# Altium schematic sheet CAN2XG.SchDoc
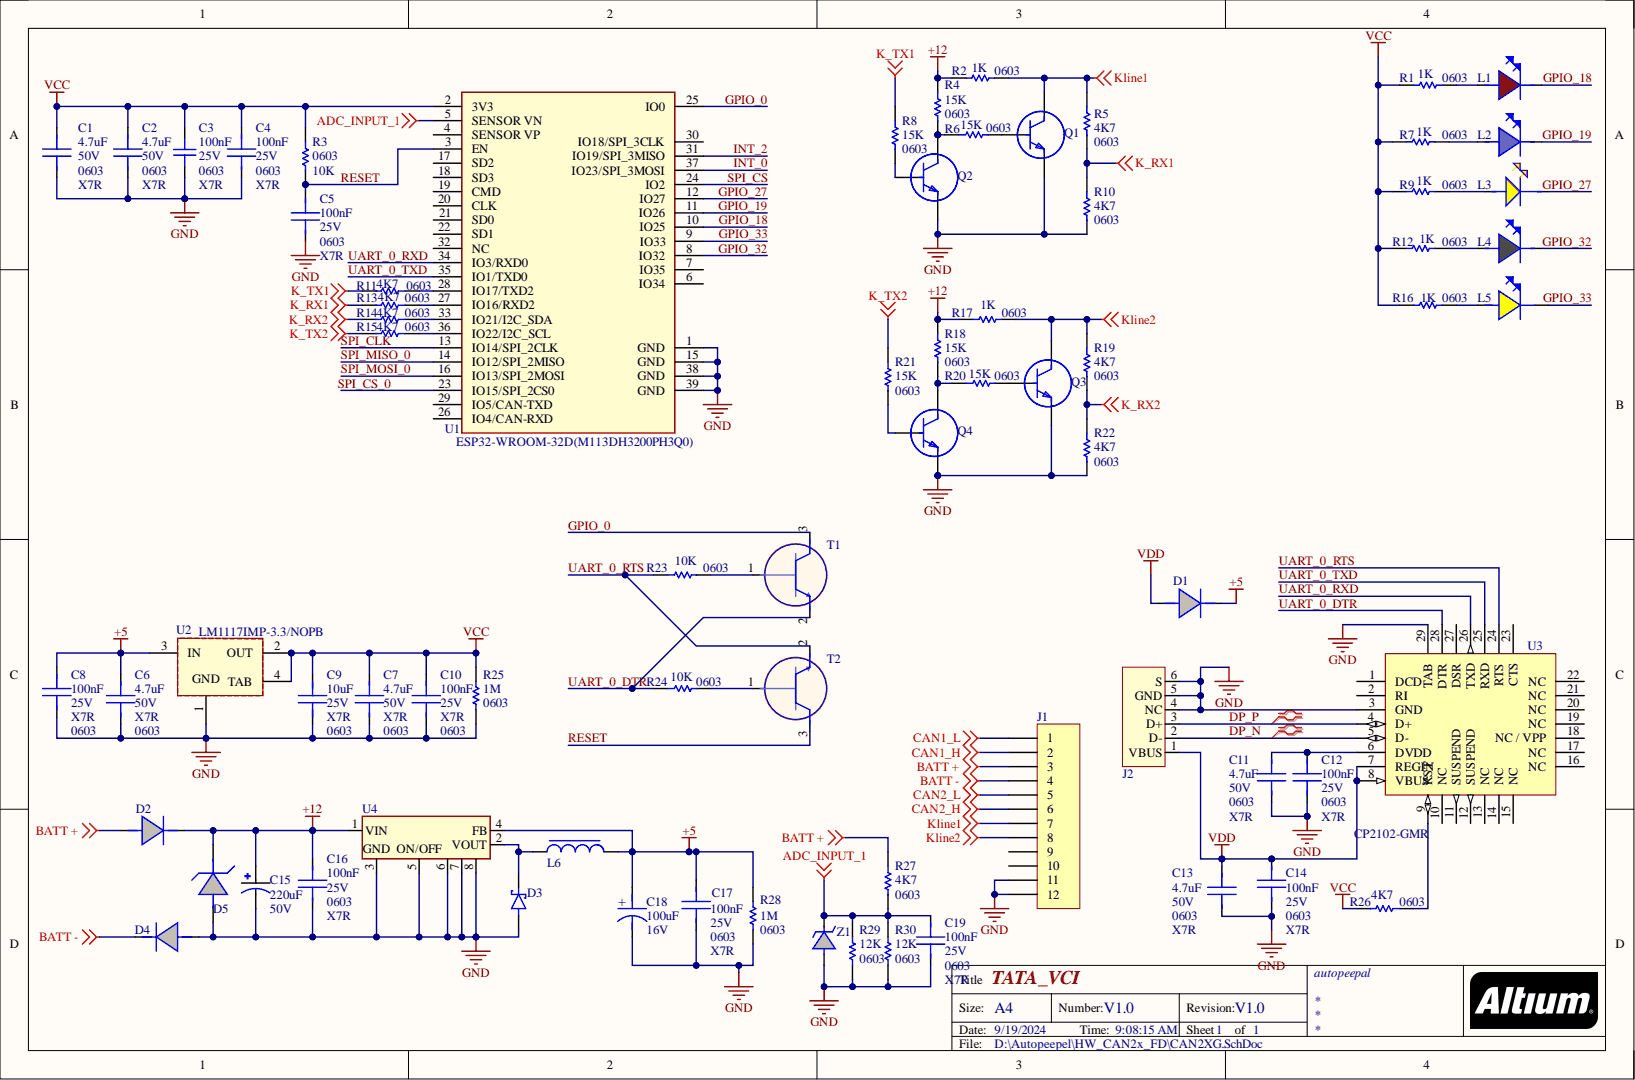
[diagram p0: CAN2XG.SchDoc]

## Parts
C1  value 4.7uF  Case/Package: 0603  Dielectric: X7R  Voltage: 50V  [CAP-0603]
C2  value 4.7uF  Case/Package: 0603  Dielectric: X7R  Voltage: 50V  [CAP-0603]
C3  value 100nF  Case/Package: 0603  Dielectric: X7R  Voltage: 25V  [CAP-0603]
C4  value 100nF  Case/Package: 0603  Dielectric: X7R  Voltage: 25V  [CAP-0603]
C5  value 100nF  Case/Package: 0603  Dielectric: X7R  Voltage: 25V  [CAP-0603]
C6  value 4.7uF  Case/Package: 0603  Dielectric: X7R  Voltage: 50V  [CAP-0603]
C7  value 4.7uF  Case/Package: 0603  Dielectric: X7R  Voltage: 50V  [CAP-0603]
C8  value 100nF  Case/Package: 0603  Dielectric: X7R  Voltage: 25V  [CAP-0603]
C9  value 10uF  Case/Package: 0603  Dielectric: X7R  Voltage: 25V  [CAP-0603]
C10  value 100nF  Case/Package: 0603  Dielectric: X7R  Voltage: 25V  [CAP-0603]
C11  value 4.7uF  Case/Package: 0603  Dielectric: X7R  Voltage: 50V  [CAP-0603]
C12  value 100nF  Case/Package: 0603  Dielectric: X7R  Voltage: 25V  [CAP-0603]
C13  value 4.7uF  Case/Package: 0603  Dielectric: X7R  Voltage: 50V  [CAP-0603]
C14  value 100nF  Case/Package: 0603  Dielectric: X7R  Voltage: 25V  [CAP-0603]
C15  value 220uF  Voltage: 50V  [C200uF 10x10.2]
C16  value 100nF  Case/Package: 0603  Dielectric: X7R  Voltage: 25V  [CAP-0603]
C17  value 100nF  Case/Package: 0603  Dielectric: X7R  Voltage: 25V  [CAP-0603]
C18  value 100uF  Voltage: 16V  [C100uF]
C19  value 100nF  Case/Package: 0603  Dielectic: X7R  Voltage: 25V  [CAP-0603]
D1  (no value)  [DO214]
D2  (no value)  [DO214]
D3  (no value)  [DO214]
D4  (no value)  [DO214]
D5  (no value)  [DO214]
J1  (no value)  [HDR1X12]
J2  (no value)  [1734035-2USB]
L1  (no value)  [LEDRED0603]
L2  (no value)  [LEDBLUE0603]
L3  (no value)  [LEDRED0603]
L4  (no value)  [LEDGREEN0603]
L5  (no value)  [LEDRED0603]
L6  (no value)  [IND-WE-PD 1050-10X10X5MM]
Q1  (no value)  [SOT95P245X93-3N]
Q2  (no value)  [SOT95P245X93-3N]
Q3  (no value)  [SOT95P245X93-3N]
Q4  (no value)  [SOT95P245X93-3N]
R1  value 1K  Case/Package: 0603  [RESC1608X55X30ML15T15]
R2  value 1K  Case/Package: 0603  [RESC1608X55X30ML15T15]
R3  value 10K  Case/Package: 0603  [RESC1608X55X30ML15T15]
R4  value 15K  Case/Package: 0603  [RESC1608X55X30ML15T15]
R5  value 4K7  Case/Package: 0603  [RESC1608X55X30ML15T15]
R6  value 15K  Case/Package: 0603  [RESC1608X55X30ML15T15]
R7  value 1K  Case/Package: 0603  [RESC1608X55X30ML15T15]
R8  value 15K  Case/Package: 0603  [RESC1608X55X30ML15T15]
R9  value 1K  Case/Package: 0603  [RESC1608X55X30ML15T15]
R10  value 4K7  Case/Package: 0603  [RESC1608X55X30ML15T15]
R11  value 4K7  Case/Package: 0603  [RESC1608X55X30ML15T15]
R12  value 1K  Case/Package: 0603  [RESC1608X55X30ML15T15]
R13  value 4K7  Case/Package: 0603  [RESC1608X55X30ML15T15]
R14  value 4K7  Case/Package: 0603  [RESC1608X55X30ML15T15]
R15  value 4K7  Case/Package: 0603  [RESC1608X55X30ML15T15]
R16  value 1K  Case/Package: 0603  [RESC1608X55X30ML15T15]
R17  value 1K  Case/Package: 0603  [RESC1608X55X30ML15T15]
R18  value 15K  Case/Package: 0603  [RESC1608X55X30ML15T15]
R19  value 4K7  Case/Package: 0603  [RESC1608X55X30ML15T15]
R20  value 15K  Case/Package: 0603  [RESC1608X55X30ML15T15]
R21  value 15K  [RESC1608X55X30ML15T15]
R22  value 4K7  Case/Package: 0603  [RESC1608X55X30ML15T15]
R23  value 10K  Case/Package: 0603  [RESC1608X55X30ML15T15]
R24  value 10K  Case/Pacake: 0603  [RESC1608X55X30ML15T15]
R25  value 1M  Case/Package: 0603  [RESC1608X55X30ML15T15]
R26  value 4K7  Case/Package: 0603  [RESC1608X55X30ML15T15]
R27  value 4K7  Case/Package: 0603  [RESC1608X55X30ML15T15]
R28  value 1M  Case/Package: 0603  [RESC1608X55X30ML15T15]
R29  value 12K  Case/Package: 0603  [RESC1608X55X30ML15T15]
R30  value 12K  Case/Package: 0603  [RESC1608X55X30ML15T15]
T1  (no value)  [2N2222]
T2  (no value)  [2N2222]
U1  (no value)  [Espressif_Systems_ESP32-WROOM-32D_MFG]
U2  (no value)  [SOT223]
U3  (no value)  [QFN50P500X500X100_HS-29N]
U4  (no value)  [to-263]
Z1  (no value)  [SOD80]

## Nets
+5: C6.2 C8.2 C17.2 C18.1 D1.1 L6.2 R28.2 U2.3(IN) U4.4(FB)
+12: C15.1 C16.2 D2.1 D5.1 R2.2 R4.2 R17.2 R18.2 U4.1(VIN)
ADC_INPUT_1: C19.2 R27.1 R29.2 R30.2 U1.5(SENSOR_VN) Z1.1
BATT +: D2.2 J1.3 R27.2
BATT -: D4.1 J1.4
CAN1_H: J1.2
CAN1_L: J1.1
CAN2_H: J1.6
CAN2_L: J1.5
GND: C1.1 C2.1 C3.1 C4.1 C5.1 C6.1 C7.1 C8.1 C9.1 C10.1 C11.1 C12.1 C13.1 C14.1 C15.2 C16.1 C17.1 C18.2 C19.1 D3.2 D4.2 D5.2 J1.11 J1.12 J2.4(NC) J2.5(GND) J2.6(S) Q1.2 Q2.2 Q3.2 Q4.2 R10.1 R22.1 R25.1 R28.1 R29.1 R30.1 U1.1(GND) U1.15(GND) U1.38(GND) U1.39(GND) U2.1 U3.3(GND) U3.29(TAB) U4.3 U4.5 U4.6 U4.7 U4.8 Z1.2
K_RX1: R5.1 R10.2 R13.1
K_RX2: R14.1 R19.1 R22.2
K_TX1: R8.2 R11.1
K_TX2: R15.1 R21.2
Kline1: J1.7 Q1.3 R2.1 R5.2
Kline2: J1.8 Q3.3 R17.1 R19.2
VCC: C1.2 C2.2 C3.2 C4.2 C7.2 C9.2 C10.2 R1.1 R3.2 R7.1 R9.1 R12.1 R16.1 R25.2 R26.1 U1.2(3V3) U2.2(OUT) U2.4(TAB)
VDD: C13.2 C14.2 D1.2 J2.1(VBUS) U3.7(REGIN) U3.8(VBUS)
DP_N: J2.2(D-) U3.5(D-)
DP_P: J2.3(D+) U3.4(D+)
GPIO_0: T1.3 U1.25(IO0)
GPIO_18: L1.1 U1.10(IO25)
GPIO_19: L2.1 U1.11(IO26)
GPIO_27: L3.1 U1.12(IO27)
GPIO_32: L4.1 U1.8(IO32)
GPIO_33: L5.1 U1.9(IO33)
INT_0: U1.37(IO23/SPI_3MOSI)
INT_2: U1.31(IO19/SPI_3MISO)
RESET: C5.2 R3.1 T2.3 U1.3(EN)
SPI_CLK: U1.13(IO14/SPI_2CLK)
SPI_CS: U1.24(IO2)
SPI_CS_0: U1.23(IO15/SPI_2CS0)
SPI_MISO_0: U1.14(IO12/SPI_2MISO)
SPI_MOSI_0: U1.16(IO13/SPI_2MOSI)
UART_0_DTR: R24.2 T1.2 U3.28(DTR)
UART_0_RTS: R23.2 T2.2 U3.24(RTS)
UART_0_RXD: U1.34(IO3/RXD0) U3.26(TXD)
UART_0_TXD: U1.35(IO1/TXD0) U3.25(RXD)
NetC11_2: C11.2 C12.2 U3.6(DVDD)
NetD3_1: D3.1 L6.1 U4.2(VOUT)
NetL1_2: L1.2 R1.2
NetL2_2: L2.2 R7.2
NetL3_2: L3.2 R9.2
NetL4_2: L4.2 R12.2
NetL5_2: L5.2 R16.2
NetQ1_1: Q1.1 R6.1
NetQ2_1: Q2.1 R8.1
NetQ2_3: Q2.3 R4.1 R6.2
NetQ3_1: Q3.1 R20.1
NetQ4_1: Q4.1 R21.1
NetQ4_3: Q4.3 R18.1 R20.2
NetR11_2: R11.2 U1.28(IO17/TXD2)
NetR13_2: R13.2 U1.27(IO16/RXD2)
NetR14_2: R14.2 U1.33(IO21/I2C_SDA)
NetR15_2: R15.2 U1.36(IO22/I2C_SCL)
NetR23_1: R23.1 T1.1
NetR24_1: R24.1 T2.1
NetR26_2: R26.2 U3.9(RST)

Not connected: J1 9 10; U1 4(SENSOR_VP) 6(IO34) 7(IO35) 17(SD2) 18(SD3) 19(CMD) 20(CLK) 21(SD0) 22(SD1) 26(IO4/CAN-RXD) 29(IO5/CAN-TXD) 30(IO18/SPI_3CLK) 32(NC); U3 1(DCD) 2(RI) 10(NC) 11(SUSPEND) 12(SUSPEND) 13(NC) 14(NC) 15(NC) 16(NC) 17(NC) 18(NC_/_VPP) 19(NC) 20(NC) 21(NC) 22(NC) 23(CTS) 27(DSR)

## Library data of the parts
C1 C2 C6 C7 C11 C13 = C4.7uF 0603: CAP CER 4.7uF 50V X7R 0603; Component Kind: Standard; Library Name: MyLib.SCHLIB
C3 C5 = C1uF 0603: CAP CER 100nF 25V X7R 0603; Component Kind: Standard; Library Name: MyLib.SCHLIB
C4 C8 C10 C12 C14 C16 C17 C19 = C100nF 0603: CAP CER 100nF 25V X7R 0603; Component Kind: Standard; Library Name: MyLib.SCHLIB
C9 = C10uF  0603: CAP CER 10uF 25V  0603; Component Kind: Standard; Library Name: MyLib.SCHLIB
C15 = C200uF 10x10.2: 220uF 50V 10x10.5mm SMD Electrolytic Capacitor; Component Kind: Standard; Library Name: FotaxLite.SCHLIB
C18 = C100uF: 100uF 16V (107C B3528) Tantalum; Component Kind: Standard; Library Name: MyLib.SCHLIB
D1 = 1N4007: DO214 SMD Version of 1N4007 Forward Current: 1A Max Repititive Reverse Voltage: 1000 V Max RMS Voltage: 700V  Max DC Blocking Voltage: 1000V; Component Kind: Standard; ComponentLink1Description: Supplier Link; ComponentLink1URL: https://www.ktron.in/product/m7-diode-sma/; Library Name: MyLib.SCHLIB; Manufacturer Part Number: 1N4007
D2 = 1N4007: DO214 SMD Version of 1N4007 Forward Current: 1A Max Repititive Reverse Voltage: 1000 V Max RMS Voltage: 700V  Max DC Blocking Voltage: 1000V Max instantaneous forward voltage: 1.1 V; Component Kind: Standard; ComponentLink1Description: Supplier Link; ComponentLink1URL: https://www.ktron.in/product/m7-diode-sma/; Library Name: MyLib.SCHLIB; Maunfacturer Part Number: 1N4007
D3 = SS34-E3/57T: Schottky Diodes & Rectifiers 3.0 Amp 40 Volt; Component Kind: Standard; Library Name: MyLib.SCHLIB; Manufacturer Part Number: SS34-E3/57T
D4 = 1N4007: DO214 SMD Version of 1N4007 Forward Current: 1A Max Repititive Reverse Voltage: 1000 V Max RMS Voltage: 700V Max DC Blocking Voltage: 1000V; Component Kind: Standard; ComponentLink1Description: Supplier Link; ComponentLink1URL: https://www.ktron.in/product/m7-diode-sma/; Library Name: MyLib.SCHLIB; Manufacturer Part Number: 1N4007
D5 = SMBJ TVS Diode: ESD Protection Diodes / TVS Diodes 600W 200V 5% Uni-Directional; Manufature Part Number: SMBJ200A; Component Kind: Standard; Library Name: MyLib.SCHLIB
J1 = Header 12: Header, 12-Pin; Component Kind: Standard; Library Name: Miscellaneous Connectors.IntLib; Signal Integrity: Connector
J2 = 1734035-2: CONN RCPT USB2.0 MINI B SMD R/A; Component Kind: Standard; Library Name: MyLib.SCHLIB; Manufacturer Part number: 1734035-2
L1 = IN-S63ATR: LED RED CLEAR 0603 SMD; Case/Packahe: 0603; Component Kind: Standard; ComponentLink1Description: http://www.inolux-corp.com/datasheet/SMDLED/Mono%20Color%20Top%20View/IN-S63AT%20Series_V1.1.pdf?src-supplier=Digi-Key; ComponentLink1URL: http://www.inolux-corp.com/datasheet/SMDLED/Mono%20Color%20Top%20View/IN-S63AT%20Series_V1.1.pdf?src-supplier=Digi-Key; Library Name: MyLib.SCHLIB; Manufacturer Part Number: IN-S63ATR
L2 = IN-S63AT5B: LED BLUE CLEAR 0603 SMD; Case/Package: 0603; Component Kind: Standard; ComponentLink1Description: http://www.inolux-corp.com/datasheet/SMDLED/Mono%20Color%20Top%20View/IN-S63AT%20Series_V1.1.pdf?src-supplier=Digi-Key; ComponentLink1URL: http://www.inolux-corp.com/datasheet/SMDLED/Mono%20Color%20Top%20View/IN-S63AT%20Series_V1.1.pdf?src-supplier=Digi-Key; Library Name: MyLib.SCHLIB; Manufacturer Part Number: IN-S63AT5B
L3 = LED_Orange0603: LED ORANGE CLEAR 0603 SMD; Case/Packahe: 0603; Component Kind: Standard; Library Name: MyLib.SCHLIB
L4 = IN-S63AT5G: LED GREEN CLEAR 0603 SMD; Case/Packahe: 0603; Component Kind: Standard; ComponentLink1Description: http://www.inolux-corp.com/datasheet/SMDLED/Mono%20Color%20Top%20View/IN-S63AT%20Series_V1.1.pdf?src-supplier=Digi-Key; ComponentLink1URL: http://www.inolux-corp.com/datasheet/SMDLED/Mono%20Color%20Top%20View/IN-S63AT%20Series_V1.1.pdf?src-supplier=Digi-Key; Library Name: MyLib.SCHLIB; Manufacturer Part Number: IN-S63AT5G
L5 = LED Y 0603: LED YELLOW CLEAR 0603 SMD; Manufacturer Part Number: IN-S63AT5Y; Case/Packahe: 0603; Component Kind: Standard; Library Name: MyLib.SCHLIB
L6 = L68uH inductor: 68uH; Component Kind: Standard; Library Name: MyLib.SCHLIB; Value: 68uH
Q1-Q4 = MMBT2222ALT1G: TRANS NPN 40V 0.6A SOT23-3; Case/Package: SOT-23-3; Component Kind: Standard; Library Name: MyLib.SCHLIB; Manufacturer Part Number: MMBT2222ALT1G
  Q2-Q4: ComponentLink1Description: https://datasheet.ciiva.com/16199/mmbt2222lt1-d-16199072.pdf?src-supplier=Future+Electronics; ComponentLink1URL: https://datasheet.ciiva.com/16199/mmbt2222lt1-d-16199072.pdf?src-supplier=Future+Electronics; ComponentLink2Description: https://datasheet.ciiva.com/15139/getdatasheetpartid-82691-15139077.pdf?src-supplier=Verical; ComponentLink2URL: https://datasheet.ciiva.com/15139/getdatasheetpartid-82691-15139077.pdf?src-supplier=Verical; ComponentLink3Description: https://datasheet.ciiva.com/7972/mmbt2222lt1-d-7972057.pdf?src-supplier=Element14; ComponentLink3URL: https://datasheet.ciiva.com/7972/mmbt2222lt1-d-7972057.pdf?src-supplier=Element14; ComponentLink4Description: https://datasheet.ciiva.com/7972/mmbt2222lt1-d-7972058.pdf?src-supplier=Element14; ComponentLink4URL: https://datasheet.ciiva.com/7972/mmbt2222lt1-d-7972058.pdf?src-supplier=Element14; ComponentLink5Description: https://datasheet.ciiva.com/14546/70099528-14546715.pdf?src-supplier=Allied+Electronics; ComponentLink5URL: https://datasheet.ciiva.com/14546/70099528-14546715.pdf?src-supplier=Allied+Electronics; ComponentLink6Description: https://datasheet.ciiva.com/25998/mmbt2222lt1-d-25998815.pdf?src-supplier=Avnet+Europe; ComponentLink6URL: https://datasheet.ciiva.com/25998/mmbt2222lt1-d-25998815.pdf?src-supplier=Avnet+Europe; ComponentLink7Description: https://datasheet.ciiva.com/976/mmbt2222lt1-d-976344.pdf?src-supplier=Digi-Key; ComponentLink7URL: https://datasheet.ciiva.com/976/mmbt2222lt1-d-976344.pdf?src-supplier=Digi-Key; ComponentLink8Description: https://datasheet.ciiva.com/976/mmbt2222lt1-d-976345.pdf?src-supplier=Digi-Key; ComponentLink8URL: https://datasheet.ciiva.com/976/mmbt2222lt1-d-976345.pdf?src-supplier=Digi-Key; ComponentLink9Description: https://datasheet.ciiva.com/976/mmbt2222lt1-d-976346.pdf?src-supplier=Digi-Key; ComponentLink9URL: https://datasheet.ciiva.com/976/mmbt2222lt1-d-976346.pdf?src-supplier=Digi-Key; ComponentLink10Description: https://datasheet.ciiva.com/11201/mmbt2222lt1-d-11201869.pdf?src-supplier=Avnet; ComponentLink10URL: https://datasheet.ciiva.com/11201/mmbt2222lt1-d-11201869.pdf?src-supplier=Avnet
R1 R7 R9 R12 R16 = R1K 0603: Thick Film Resistor -  1K, 1%, 0603; Component Kind: Standard; Library Name: MyLib.SCHLIB
R2 R17 = R1K 0603: Thick Film Resistor -  1K ohm, 1%, 0603; Component Kind: Standard; Library Name: MyLib.SCHLIB
R3 = R10K 0603: Thick Film Resistor -  10Kohm, 1%, 0603; Component Kind: Standard; Library Name: MyLib.SCHLIB
R4 R6 R8 R18 R20 R21 = R_15K: Thick Film Resistor -  15Kohm, 1%, 0603; Component Kind: Standard; Library Name: MyLib.SCHLIB
  R21: Case/Package: 0603
R5 R10 R26 = R_4k7: Thick Film Resistor -  4K7 ohm, 1%, 0603; Component Kind: Standard; Library Name: MyLib.SCHLIB
R11 R13-R15 = R_4k7: Thick Film Resistor -  4K7, 1%, 0603; Component Kind: Standard; Library Name: MyLib.SCHLIB
R19 R22 = R_4k7: Thick Film Resistor -  4K7ohm, 1%, 0603; Component Kind: Standard; Library Name: MyLib.SCHLIB
R23 R24 = R10K 0603: Thick Film Resistor -  10K, 1%, 0603; Component Kind: Standard; Library Name: MyLib.SCHLIB
R25 R28 = R1MOhm 0603: Thick Film Resistor -  10M, 1%, 0603; Component Kind: Standard; Library Name: MyLib.SCHLIB
R27 = R_47K: Thick Film Resistor -  4K7 ohm, 1%, 0603; Component Kind: Standard; Library Name: MyLib.SCHLIB
R29 R30 = R12K 0603: Thick Film Resistor -  12K ohm, 1%, 0603; Component Kind: Standard; Library Name: MyLib.SCHLIB
T1 T2 = MMBT2222: 2TRANS NPN 30V 0.6A SOT23-3; Component Kind: Standard; Library Name: MyLib.SCHLIB; Manufacturer Part Number: MMBT2222
U1 = ESP32-WROOM-32D(M113DH3200PH3Q0): WiFi Modules (802.11) SMD Module, ESP32-D0WD, 32Mbits SPI 4MB flash, UART mode, PCB antenna; Component Kind: Standard; ComponentLink1Description: Link; ComponentLink1URL: https://www.mouser.com/ProductDetail/Espressif-Systems/ESP32-WROOM-32D-N4?qs=Li%252BoUPsLEntUgv1ft2QYWQ%3D%3D; Library Name: MyLib.SCHLIB; Manufacturer Part Number: ESP32-WROOM-32D(M113DH3200PH3Q0)
U2 = LM1117IMP-3.3/NOPB: LDO Voltage Regulators 800MA LDO LINEAR REG; Component Kind: Standard; Library Name: MyLib.SCHLIB; Manufacturer Part Number: LM1117IMP-3.3/NOPB
U3 = CP2102-GMR: USB-to-UART 1-CH 640byte FIFO 3.3V Automotive 28-Pin QFN EP T/R; Component Kind: Standard; Library Name: MyLib.SCHLIB; Manufacturer Part Number: CP2102-GMR
U4 = LM2596S-5.0/NOPB: Switching Voltage Regulators 150 KHZ 3A STEP-DOWN VLTG REG; Component Kind: Standard; Library Name: MyLib.SCHLIB; Manufacturer Part Number: LM2596S-5.0/NOPB
Z1 = Zener5.1V: Zener Diode 5.1V  500mW  5% Tolerance  SMD Equivalent of BZX55C5V1; Case/Package: SOD-80-2; Component Kind: Standard; ComponentLink1Description: Supplier Link; ComponentLink1URL: https://www.ktron.in/product/zener-diode-5v1-500mw-sod-80-2/; Library Name: MyLib.SCHLIB; Voltage: 5.1V
